# Altium schematic sheet 4_NRF9160.SchDoc(4_NRF9160)
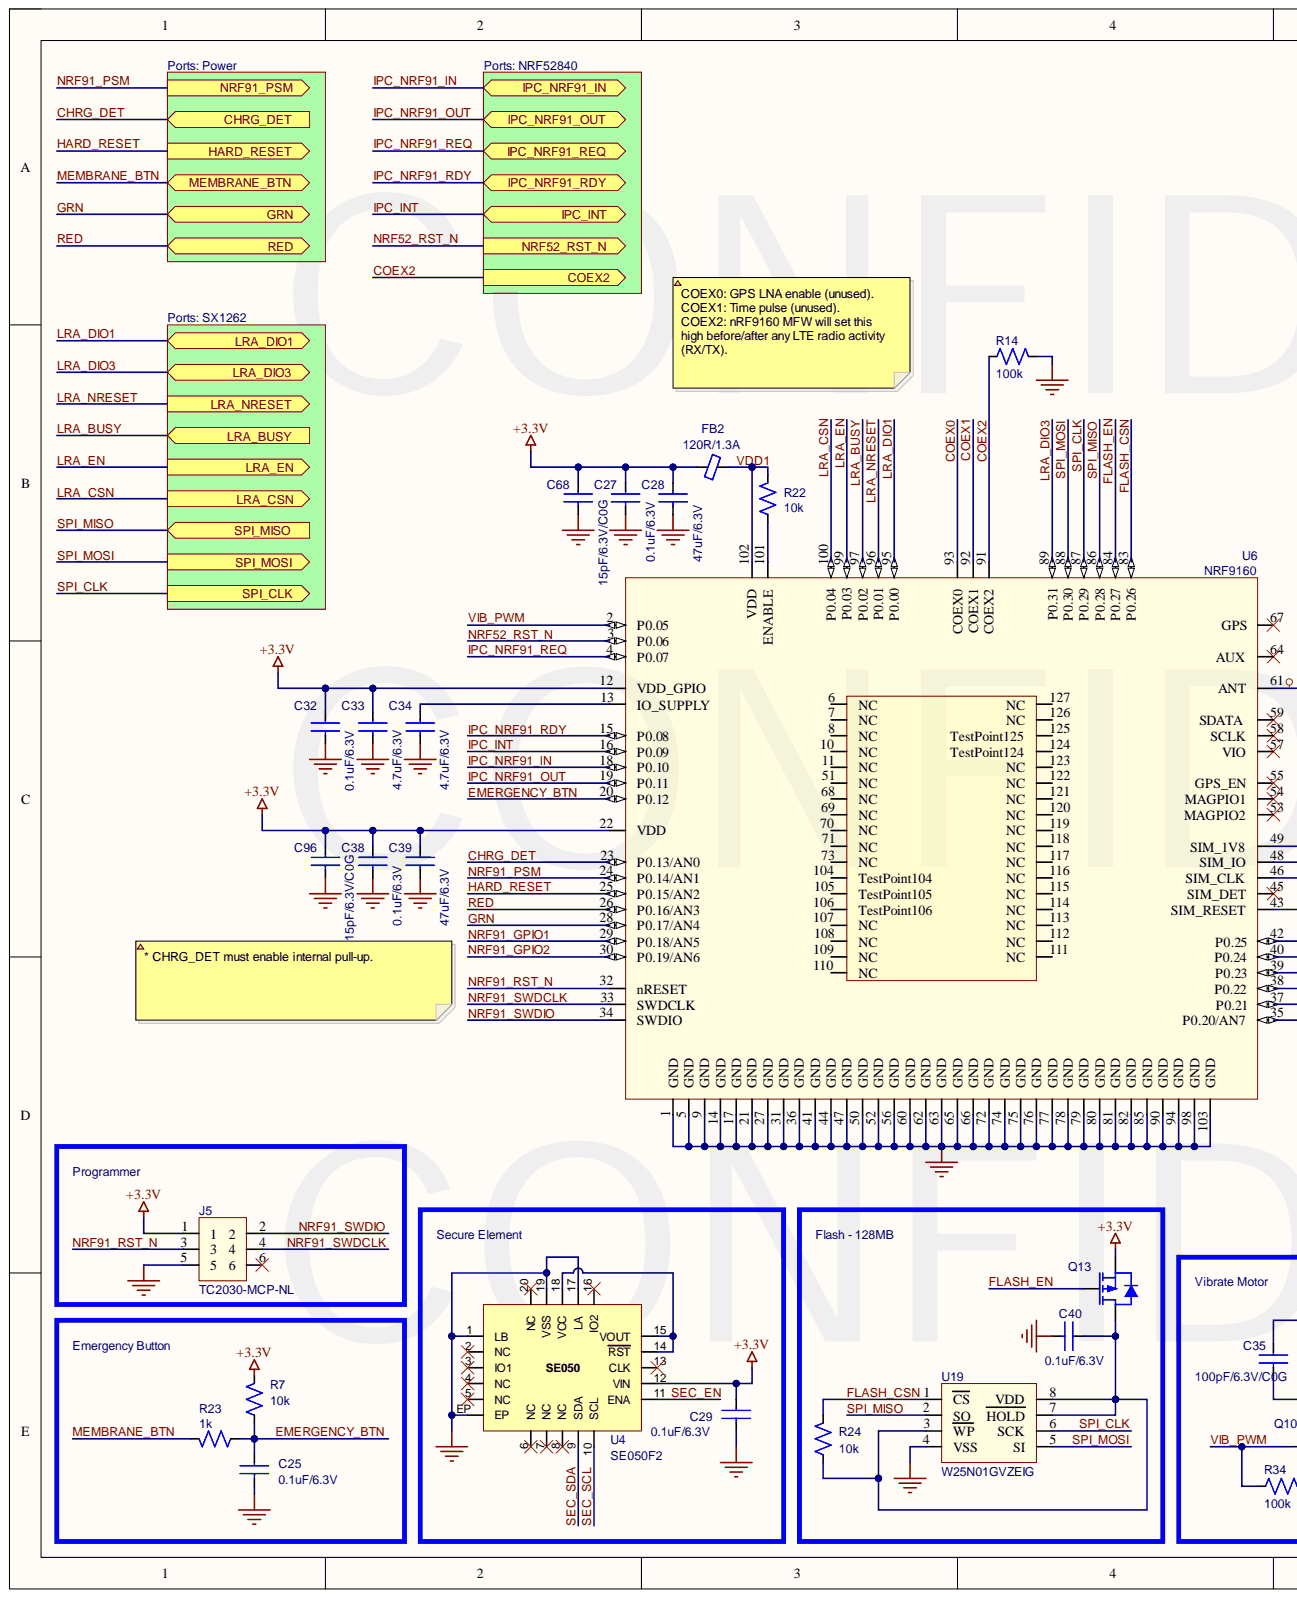
[diagram p0: 4_NRF9160.SchDoc, left]
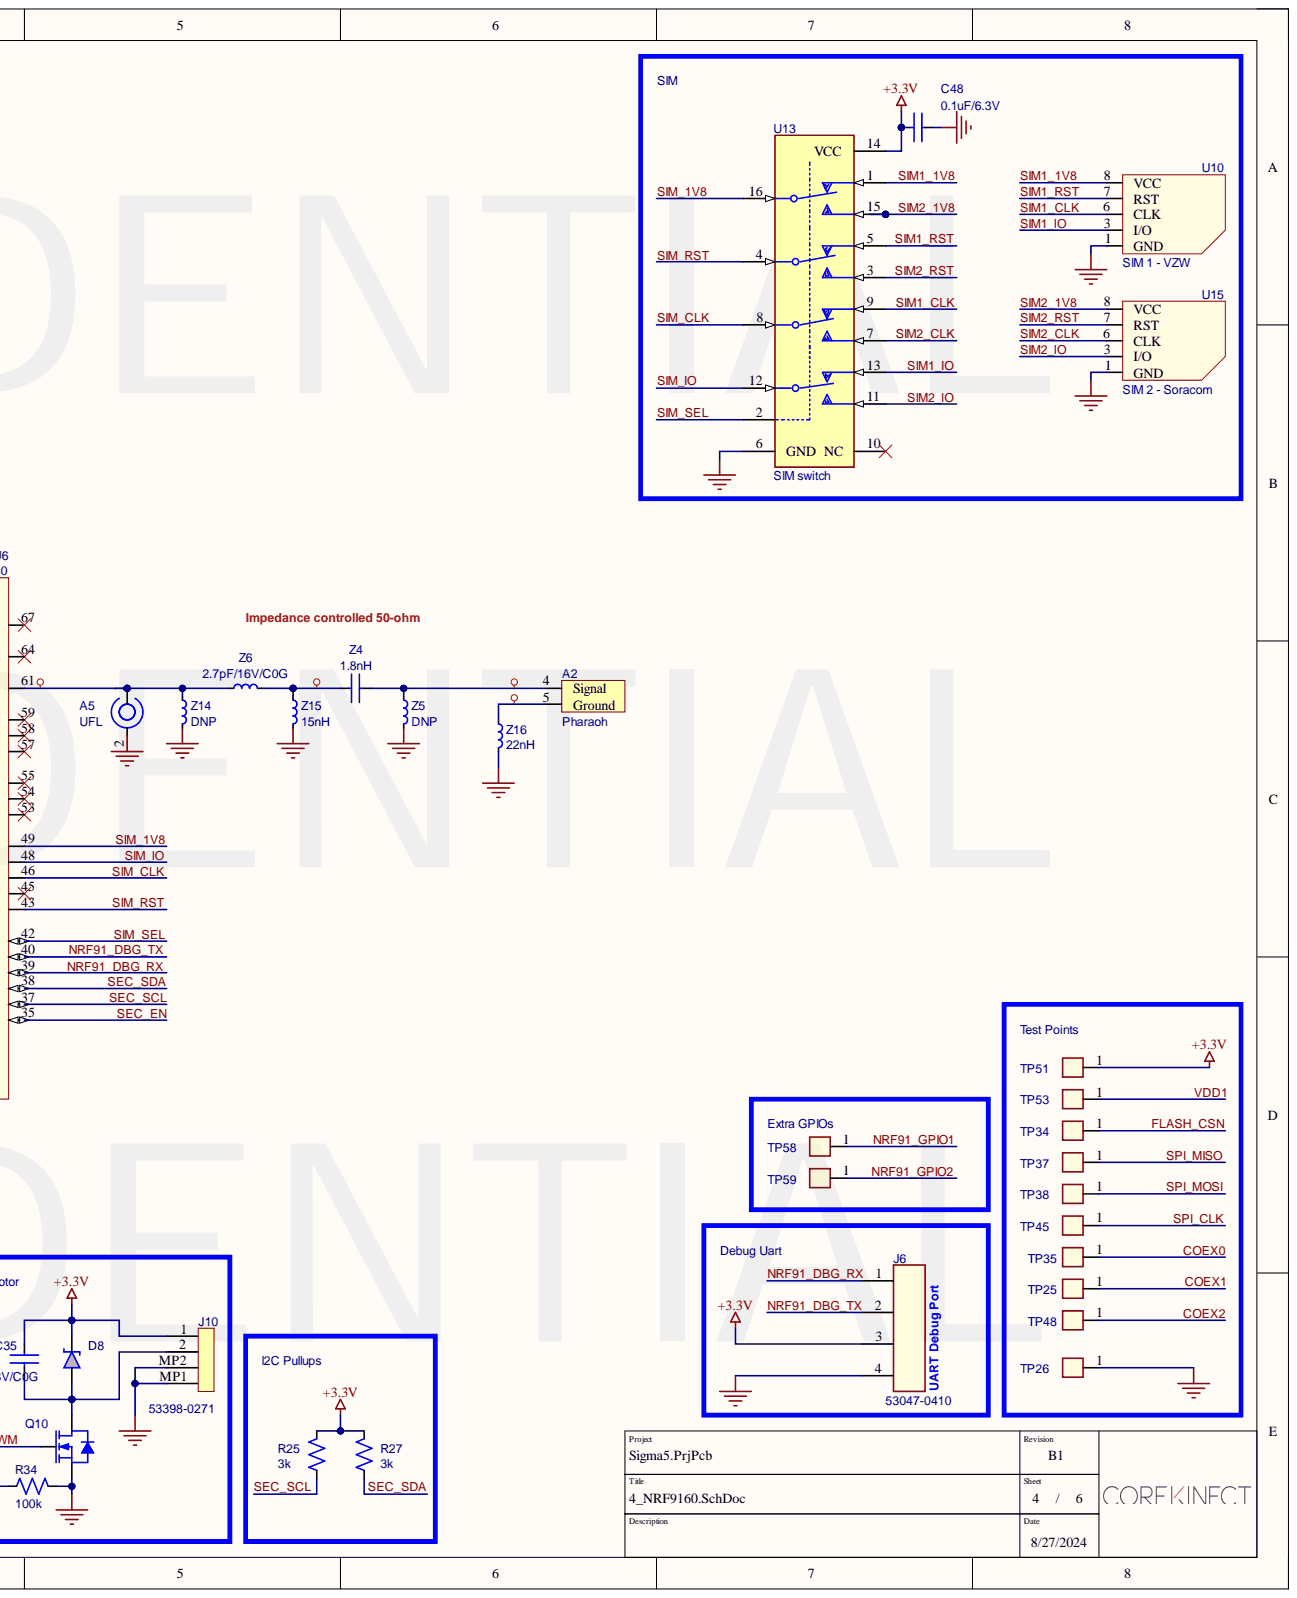
[diagram p1: 4_NRF9160.SchDoc, right]

## Parts
A2  Pharaoh  [Pharaoh_Ant]
A5  UFL  [U.FL-INLINE]
C25  0.1uF/6.3V  [CAP_0402]
C27  0.1uF/6.3V  [CAP_0402]
C28  47uF/6.3V  [SMT_0805]
C29  0.1uF/6.3V  [CAP_0402]
C32  0.1uF/6.3V  [CAP_0402]
C33  4.7uF/6.3V  [CAP_0603]
C34  4.7uF/6.3V  [CAP_0603]
C35  100pF/6.3V/C0G  [CAP_0402]
C38  0.1uF/6.3V  [CAP_0402]
C39  47uF/6.3V  [SMT_0805]
C40  0.1uF/6.3V  [CAP_0402]
C48  0.1uF/6.3V  [CAP_0402]
C68  15pF/6.3V/C0G  [CAP_0402]
C96  15pF/6.3V/C0G  [CAP_0402]
D8  B140HW-7  [SOD123]  (value not drawn)
FB2  120R/1.3A  [CAP_0402]
J5  TC2030-MCP-NL  [TC2030-MCP-NL]
J6  53047-0410  [0530470410_Molex]
J10  53398-0271  [MOLEX-53398-0271_V]
Q10  DMG1012UW-7  [SOT-323]  (value not drawn)
Q13  DMG1013UW-7  [SOT-323]  (value not drawn)
R7  10k  [RES_0402]
R14  100k  [RES_0402]
R22  10k  [RES_0402]
R23  1k  [RES_0402]
R24  10k  [RES_0402]
R25  3k  [RES_0402]
R27  3k  [RES_0402]
R34  100k  [RES_0402]
TP25  TP  [SMT_TP35_SM]
TP26  TP  [SMT_TP35_SM]
TP34  TP  [SMT_TP35_SM]
TP35  TP  [SMT_TP35_SM]
TP37  TP  [SMT_TP35_SM]
TP38  TP  [SMT_TP35_SM]
TP45  TP  [SMT_TP35_SM]
TP48  TP  [SMT_TP35_SM]
TP51  TP  [SMT_TP35_SM]
TP53  TP  [SMT_TP35_SM]
TP58  TP  [SMT_TP35_SM]
TP59  TP  [SMT_TP35_SM]
U4  SE050F2  [NXP_SE050]
U6  NRF9160  [nRF9160_DEV2_MODULE]
U10  SIM 1 - VZW  [SIM-ST-MFF2]
U13  SIM switch  [FP-523BF-MFG]
U15  SIM 2 - Soracom  Manufacturer 1: Soracom  [SIM-ST-MFF2]
U19  W25N01GVZEIG  [WSON-8_8x6mm]
Z4  1.8nH  [CAP_0402]
Z5  DNP  [CAP_0402]
Z6  2.7pF/16V/C0G  [CAP_0402]
Z14  DNP  [CAP_0402]
Z15  15nH  [CAP_0402]
Z16  22nH  [CAP_0402]

## Ports
CHRG_DET, COEX2, GRN, HARD_RESET, IPC_INT, IPC_NRF91_IN, IPC_NRF91_OUT, IPC_NRF91_RDY, IPC_NRF91_REQ, LRA_BUSY, LRA_CSN, LRA_DIO1, LRA_DIO3, LRA_EN, LRA_NRESET, MEMBRANE_BTN, NRF52_RST_N, NRF91_PSM, RED, SPI_CLK, SPI_MISO, SPI_MOSI

## Nets
+3.3V: C27.1 C28.1 C29.1 C32.1 C33.1 C35.1 C38.1 C39.1 C48.2 C68.1 C96.1 D8.1 FB2.1 J5.1 J6.3 J10.1 Q13.2 R7.2 R25.2 R27.2 TP51.1 U4.12(VIN) U6.12(VDD_GPIO) U6.22(VDD) U13.14(VCC)
GND: A5.2 C25.2 C27.2 C28.2 C29.2 C32.2 C33.2 C34.2 C38.2 C39.2 C40.2 C48.1 C68.2 C96.2 J5.5 J6.4 J10.MP1 J10.MP2 Q10.2 R14.2 R34.2 TP26.1 U4.1(LB) U4.17(LA) U4.19(VSS) U4.EP U6.1(GND) U6.5(GND) U6.9(GND) U6.14(GND) U6.17(GND) U6.21(GND) U6.27(GND) U6.31(GND) U6.36(GND) U6.41(GND) U6.44(GND) U6.47(GND) U6.50(GND) U6.52(GND) U6.56(GND) U6.60(GND) U6.62(GND) U6.63(GND) U6.65(GND) U6.66(GND) U6.72(GND) U6.74(GND) U6.75(GND) U6.76(GND) U6.77(GND) U6.78(GND) U6.79(GND) U6.80(GND) U6.81(GND) U6.82(GND) U6.85(GND) U6.90(GND) U6.94(GND) U6.98(GND) U6.103(GND) U10.1(GND) U13.6(GND) U15.1(GND) U19.4(VSS) Z5.2 Z14.2 Z15.2 Z16.2
CHRG_DET: U6.23(P0.13/AN0) ; port CHRG_DET
COEX0: TP35.1 U6.93(COEX0)
COEX1: TP25.1 U6.92(COEX1)
COEX2: R14.1 TP48.1 U6.91(COEX2) ; port COEX2
EMERGENCY_BTN: C25.1 R7.1 R23.1 U6.20(P0.12)
FLASH_CSN: R24.1 TP34.1 U6.83(P0.26) U19.1(CS)
FLASH_EN: Q13.1 U6.84(P0.27)
GRN: U6.28(P0.17/AN4) ; port GRN
HARD_RESET: U6.25(P0.15/AN2) ; port HARD_RESET
IPC_INT: U6.16(P0.09) ; port IPC_INT
IPC_NRF91_IN: U6.18(P0.10) ; port IPC_NRF91_IN
IPC_NRF91_OUT: U6.19(P0.11) ; port IPC_NRF91_OUT
IPC_NRF91_RDY: U6.15(P0.08) ; port IPC_NRF91_RDY
IPC_NRF91_REQ: U6.4(P0.07) ; port IPC_NRF91_REQ
LRA_BUSY: U6.97(P0.02) ; port LRA_BUSY
LRA_CSN: U6.100(P0.04) ; port LRA_CSN
LRA_DIO1: U6.95(P0.00) ; port LRA_DIO1
LRA_DIO3: U6.89(P0.31) ; port LRA_DIO3
LRA_EN: U6.99(P0.03) ; port LRA_EN
LRA_NRESET: U6.96(P0.01) ; port LRA_NRESET
MEMBRANE_BTN: R23.2 ; port MEMBRANE_BTN
NRF52_RST_N: U6.3(P0.06) ; port NRF52_RST_N
NRF91_DBG_RX: J6.1 U6.39(P0.23)
NRF91_DBG_TX: J6.2 U6.40(P0.24)
NRF91_GPIO1: TP58.1 U6.29(P0.18/AN5)
NRF91_GPIO2: TP59.1 U6.30(P0.19/AN6)
NRF91_PSM: U6.24(P0.14/AN1) ; port NRF91_PSM
NRF91_RST_N: J5.3 U6.32(nRESET)
NRF91_SWDCLK: J5.4 U6.33(SWDCLK)
NRF91_SWDIO: J5.2 U6.34(SWDIO)
RED: U6.26(P0.16/AN3) ; port RED
SEC_EN: U4.11(ENA) U6.35(P0.20/AN7)
SEC_SCL: R25.1 U4.10(SCL) U6.37(P0.21)
SEC_SDA: R27.1 U4.9(SDA) U6.38(P0.22)
SIM1_1V8: U10.8(VCC) U13.1
SIM1_CLK: U10.6(CLK) U13.9
SIM1_IO: U10.3(I/O) U13.13
SIM1_RST: U10.7(RST) U13.5
SIM2_1V8: U13.15 U15.8(VCC)
SIM2_CLK: U13.7 U15.6(CLK)
SIM2_IO: U13.11 U15.3(I/O)
SIM2_RST: U13.3 U15.7(RST)
SIM_1V8: U6.49(SIM_1V8) U13.16
SIM_CLK: U6.46(SIM_CLK) U13.8
SIM_IO: U6.48(SIM_IO) U13.12
SIM_RST: U6.43(SIM_RESET) U13.4
SIM_SEL: U6.42(P0.25) U13.2
SPI_CLK: TP45.1 U6.87(P0.29) U19.6(SCK) ; port SPI_CLK
SPI_MISO: TP37.1 U6.86(P0.28) U19.2(SO) ; port SPI_MISO
SPI_MOSI: TP38.1 U6.88(P0.30) U19.5(SI) ; port SPI_MOSI
VDD1: FB2.2 R22.2 TP53.1 U6.102(VDD)
VIB_PWM: Q10.1 R34.1 U6.2(P0.05)
NetA2_4: A2.4 Z4.2 Z5.1
NetA2_5: A2.5 Z16.1
NetA5_1: A5.1 U6.61(ANT) Z6.1 Z14.1
NetC34_1: C34.1 U6.13(IO_SUPPLY)
NetC35_2: C35.2 D8.2 J10.2 Q10.3
NetC40_1: C40.1 Q13.3 R24.2 U19.3(WP) U19.7(HOLD) U19.8(VDD)
NetR22_1: R22.1 U6.101(ENABLE)
NetU4_14: U4.14(RST) U4.15(VOUT) U4.18(VCC)
NetZ4_1: Z4.1 Z6.2 Z15.1

Not connected: J5 6; U4 2(NC) 3(IO1) 4(NC) 5(NC) 6(NC) 7(NC) 8(NC) 13(CLK) 16(IO2) 20(NC); U6 6(NC) 7(NC) 8(NC) 10(NC) 11(NC) 45(SIM_DET) 51(NC) 53(MAGPIO2) 54(MAGPIO1) 55(GPS_EN) 57(VIO) 58(SCLK) 59(SDATA) 64(AUX) 67(GPS) 68(NC) 69(NC) 70(NC) 71(NC) 73(NC) 104(TestPoint104) 105(TestPoint105) 106(TestPoint106) 107(NC) 108(NC) 109(NC) 110(NC) 111(NC) 112(NC) 113(NC) 114(NC) 115(NC) 116(NC) 117(NC) 118(NC) 119(NC) 120(NC) 121(NC) 122(NC) 123(NC) 124(TestPoint124) 125(TestPoint125) 126(NC) 127(NC); U13 10(NC)

## Library data of the parts
A2 = SR4L073: Antenna Cellular -6dBi Gain 960MHz/2200MHz/2400MHz/2690MHz 18-Pin SMD; Manufacturer: Antenova; Notes: LTE antenna; Component Kind: Standard; Manufacturer Part Number: SR4L073; Pricing 1: 1=5.19, 10=4.663, 25=4.4088, 100=3.8208, 250=3.62488 (USD); RoHS: No; Stock 1: 502; Supplier 1: Digi-Key; Supplier Part Number 1: 627-SR4L073DKR-ND; Library Name: CoreKinectIntegratedLibrary.IntLib
A5 = UFL_INLINE: Component Kind: Standard; Library Name: CoreKinectIntegratedLibrary.IntLib
C25 C27 C29 C32 C35 C38 C40 C48 C68 C96 = CAP_0402: Component Kind: Standard; Package / Case: 0402; Library Name: CoreKinectIntegratedLibrary.IntLib
C28 C39 = CAP_0805: Component Kind: Standard; Package / Case: 0805; Library Name: CoreKinectIntegratedLibrary.IntLib
C33 C34 = CAP_0603: Component Kind: Standard; Package / Case: 0603; Library Name: CoreKinectIntegratedLibrary.IntLib
D8 = B140HW-7: Diode Schottky 40V 1A 2Pin SOD123; Manufacturer 1: Diodes; Element Configuration: Single; Forward Voltage: 550mV; Max Forward Surge Current (Ifsm): 16A; Max Power Dissipation: 410mW; Max Reverse Current: 40uA; Polarity: Standard; Average Rectified Current: 1A; Category: Rectifier Diodes; Component Kind: Standard; ComponentLink1Description: https://datasheet.ciiva.com/25946/ds30670-25946913.pdf?src-supplier=Avnet+Europe; ComponentLink1URL: https://datasheet.ciiva.com/25946/ds30670-25946913.pdf?src-supplier=Avnet+Europe; ComponentLink2Description: https://datasheet.ciiva.com/4715/ds30670-86720-4715619.pdf?src-supplier=Mouser; ComponentLink2URL: https://datasheet.ciiva.com/4715/ds30670-86720-4715619.pdf?src-supplier=Mouser; ComponentLink3Description: https://datasheet.ciiva.com/26788/b140hw-7-26788582.pdf?src-supplier=IIIC; ComponentLink3URL: https://datasheet.ciiva.com/26788/b140hw-7-26788582.pdf?src-supplier=IIIC; ComponentLink4Description: https://datasheet.ciiva.com/912/ds30670-912807.pdf?src-supplier=Digi-Key; ComponentLink4URL: https://datasheet.ciiva.com/912/ds30670-912807.pdf?src-supplier=Digi-Key; ComponentLink5Description: https://datasheet.ciiva.com/912/ds30670-912808.pdf?src-supplier=Digi-Key; ComponentLink5URL: https://datasheet.ciiva.com/912/ds30670-912808.pdf?src-supplier=Digi-Key; ComponentLink6Description: https://datasheet.ciiva.com/912/ds30670-912809.pdf?src-supplier=Digi-Key; ComponentLink6URL: https://datasheet.ciiva.com/912/ds30670-912809.pdf?src-supplier=Digi-Key; ComponentLink7Description: https://datasheet.ciiva.com/8301/ds30670-8301643.pdf?src-supplier=Avnet; ComponentLink7URL: https://datasheet.ciiva.com/8301/ds30670-8301643.pdf?src-supplier=Avnet; ComponentLink8Description: https://datasheet.ciiva.com/8303/ds30670-8303271.pdf?src-supplier=Avnet; ComponentLink8URL: https://datasheet.ciiva.com/8303/ds30670-8303271.pdf?src-supplier=Avnet; ComponentLink9Description: https://datasheet.ciiva.com/1177/ds30670-1177415.pdf?src-supplier=Element14; ComponentLink9URL: https://datasheet.ciiva.com/1177/ds30670-1177415.pdf?src-supplier=Element14; ComponentLink10Description: https://datasheet.ciiva.com/15220/getdatasheetpartid-245799-15220198.pdf?src-supplier=Verical; ComponentLink10URL: https://datasheet.ciiva.com/15220/getdatasheetpartid-245799-15220198.pdf?src-supplier=Verical; Contact Plating: Tin,Matte; Current Rating: 1A; Forward Current: 1A; Height: 1.25mm; Lead Free: Lead Free; Length: 2.85mm; Manufacturer Part Number 1: B140HW-7; Max Operating Temperature: 125°C; Max Repetitive Reverse Voltage (Vrrm): 40V; Max Reverse Voltage (DC): 40V; Min Operating Temperature: -65°C; Mount: Surface Mount; Number of Pins: 2; Output Current: 1A; Package Quantity: 3000; Packaging: Tape and Reel; Peak Non-Repetitive Surge Current: 16A; Pricing 1: 3000=0.08800, 6000=0.07920, 15000=0.07040, 30000=0.06680 (USD); Radiation Hardening: No; REACH SVHC: No SVHC; RoHS: Yes; RoHS Compliant: Yes; Series: -; Stock 1: 84000; Supplier 1: Digi-Key; Supplier Part Number 1: B140HWDITR-ND; Voltage Rating (DC): 40V; Weight: 0.000353oz; Width: 1.7mm; Library Name: CoreKinectIntegratedLibrary.IntLib
FB2 = BLM15PD121SN1D: Ferrite Bead, 120 ohm @ 100MHz, 1.3 A, BLM15PD Series, 0.09 ohm, ± 25%; Manufacturer 1: Murata; Current Rating: 1.3A; DC Resistance (DCR): 90mR; Impedance: 120R; Material: Steel,Ferrite; Number of Circuits: 1; Case Code (Imperial): 0402; Case Code (Metric): 1005; Case/Package: 0402; Category: Inductors; Component Kind: Standard; ComponentLink1Description: https://datasheet.ciiva.com/18093/getdatasheetpartid-195858-18093157.pdf?src-supplier=Verical; ComponentLink1URL: https://datasheet.ciiva.com/18093/getdatasheetpartid-195858-18093157.pdf?src-supplier=Verical; ComponentLink2Description: https://datasheet.ciiva.com/11800/0900766b811b5866-11800860.pdf?src-supplier=RSComponents; ComponentLink2URL: https://datasheet.ciiva.com/11800/0900766b811b5866-11800860.pdf?src-supplier=RSComponents; ComponentLink3Description: https://datasheet.ciiva.com/41425/001171686-da-01-en-chip-drossel0402-120r-1300ma-1-0x0-5mm-41425776.pdf?src-supplier=Conrad; ComponentLink3URL: https://datasheet.ciiva.com/41425/001171686-da-01-en-chip-drossel0402-120r-1300ma-1-0x0-5mm-41425776.pdf?src-supplier=Conrad; ComponentLink4Description: https://datasheet.ciiva.com/41449/001172205-da-01-en-drossel-0402-120r-11-0x0-5mm-10000st-41449495.pdf?src-supplier=Conrad; ComponentLink4URL: https://datasheet.ciiva.com/41449/001172205-da-01-en-drossel-0402-120r-11-0x0-5mm-10000st-41449495.pdf?src-supplier=Conrad; ComponentLink5Description: https://datasheet.ciiva.com/1012/l0110e-1012436.pdf?src-supplier=Digi-Key; ComponentLink5URL: https://datasheet.ciiva.com/1012/l0110e-1012436.pdf?src-supplier=Digi-Key; ComponentLink6Description: https://datasheet.ciiva.com/1012/l0110e-1012434.pdf?src-supplier=Digi-Key; ComponentLink6URL: https://datasheet.ciiva.com/1012/l0110e-1012434.pdf?src-supplier=Digi-Key; ComponentLink7Description: https://datasheet.ciiva.com/1012/l0110e-1012435.pdf?src-supplier=Digi-Key; ComponentLink7URL: https://datasheet.ciiva.com/1012/l0110e-1012435.pdf?src-supplier=Digi-Key; Core Material: Ferrite; DC Current: 1.3A; DC Resistance-Max: 90mR; Depth: 0.55mm; Height: 0.5mm; Lead Free: Lead Free; Length: 1mm; Manufacturer Part Number 1: BLM15PD121SN1D; Max Current Rating: 1.3A; Max DC Current: 1.3A; Max Operating Temperature: 125°C; Military Standard: Not; Min Operating Temperature: -55°C; Number of Lines: 1; Number of Pins: 2; Package Quantity: 1; Packaging: Tape and Reel; Pricing 1: 10000=0.01914, 20000=0.01848, 30000=0.01716, 50000=0.01696 (USD); Radiation Hardening: No; REACH SVHC: No SVHC; RoHS: Yes; RoHS Compliant: Yes; Series: BLM15PD; Stock 1: 240000; Supplier 1: Digi-Key; Supplier Part Number 1: 490-5203-2-ND; Termination: SMD/SMT; Test Frequency: 100MHz; Tolerance: 25%; Width: 0.5mm; Library Name: CoreKinectIntegratedLibrary.IntLib
J5 = TC2030-MCP-NL: CABLE TAG-CONNECT IN-CIRCUIT; Component Kind: Standard; PN: TC2030-MCP-NL; Library Name: Project Zenith.SchLib
J6 = MOLEX_53047-0410: GLOVE, POLYAMIDE, L/FREE, PU, SIZE 6 - More Details; Manufacturer 1: Molex; Contact Resistance: 20mR; Current: 1A; Gender: Male; Glow Wire Compliant: Non-compliant; Insulation Resistance: 100MR; Max Voltage Rating (AC): 125V; Number of Circuits: 4; Pitch: 1.25mm; Plating: Tin; Case/Package: 0410; Category: Headers and Wire Housings; Color: Natural; Component Kind: Standard; ComponentLink1Description: https://datasheet.ciiva.com/7844/1838335-7844032.pdf?src-supplier=Element14; ComponentLink1URL: https://datasheet.ciiva.com/7844/1838335-7844032.pdf?src-supplier=Element14; ComponentLink2Description: https://datasheet.ciiva.com/30144/347020530470410-pcb-headers-30144214.pdf?src-supplier=Onlinecomponents.com; ComponentLink2URL: https://datasheet.ciiva.com/30144/347020530470410-pcb-headers-30144214.pdf?src-supplier=Onlinecomponents.com; ComponentLink3Description: https://datasheet.ciiva.com/20207/22-3930e-20207527.pdf?src-supplier=Rapid+Electronics; ComponentLink3URL: https://datasheet.ciiva.com/20207/22-3930e-20207527.pdf?src-supplier=Rapid+Electronics; ComponentLink4Description: https://datasheet.ciiva.com/5149/0530470410-pcb-headers-170953-5149004.pdf?src-supplier=Mouser; ComponentLink4URL: https://datasheet.ciiva.com/5149/0530470410-pcb-headers-170953-5149004.pdf?src-supplier=Mouser; ComponentLink5Description: https://datasheet.ciiva.com/11746/0900766b8024e2fd-11746351.pdf?src-supplier=RSComponents; ComponentLink5URL: https://datasheet.ciiva.com/11746/0900766b8024e2fd-11746351.pdf?src-supplier=RSComponents; ComponentLink6Description: https://datasheet.ciiva.com/16658/0530470410-pcb-headers-16658419.pdf?src-supplier=Avnet+Europe; ComponentLink6URL: https://datasheet.ciiva.com/16658/0530470410-pcb-headers-16658419.pdf?src-supplier=Avnet+Europe; ComponentLink7Description: https://datasheet.ciiva.com/27054/1932483-27054996.pdf?src-supplier=Jameco; ComponentLink7URL: https://datasheet.ciiva.com/27054/1932483-27054996.pdf?src-supplier=Jameco; ComponentLink8Description: https://datasheet.ciiva.com/14156/ge116819-116832-e-14156235.pdf?src-supplier=Distrelec; ComponentLink8URL: https://datasheet.ciiva.com/14156/ge116819-116832-e-14156235.pdf?src-supplier=Distrelec; ComponentLink9Description: https://datasheet.ciiva.com/10088/0530470410-pcb-headers-10088984.pdf?src-supplier=Avnet; ComponentLink9URL: https://datasheet.ciiva.com/10088/0530470410-pcb-headers-10088984.pdf?src-supplier=Avnet; Contact Material: Bronze,Tin; Contact Plating: Tin; Current Rating: 1A; Flammability Rating: UL94 V-0; Housing Color: Natural; Housing Material: Polyamide; Lead Free: Lead Free; Manufacturer Part Number 1: 53047-0410; Max Operating Temperature: 85°C; Min Operating Temperature: -40°C; Mount: Through Hole; Number of Contacts: 4; Number of Positions: 4; Number of Rows: 1; Orientation: Vertical; Package Quantity: 5000; Packaging: Tray; Pricing 1: 1=0.28000, 10=0.26100, 100=0.18300, 500=0.15688, 1000=0.13073, 5000=0.11205, 10000=0.10458 (USD); Radiation Hardening: No; REACH SVHC: Unknown; RoHS: Yes; Stock 1: 47330; Supplier 1: Digi-Key; Supplier Part Number 1: WM1733-ND; Termination: Solder; Voltage: 125V; Voltage Rating: 125V; Voltage Rating (AC): 125V; Voltage Rating (DC): 125V; Working Voltage: 125V; Library Name: CoreKinectIntegratedLibrary.IntLib
J10 = MOLEX_53398-0271: 1.25mm (.049") Pitch PicoBlade Header, Surface Mount, Vertical, Lead-free, 2 Circuits; Manufacturer: Molex; Contact Resistance: 20mR; Current Rating: 1A; Gender: Male; Glow Wire Compliant: Non-compliant; Insulation Resistance: 100MR; Number of Circuits: 2; Voltage Rating: 125V; Category: Headers and Wire Housings; Color: Natural; Component Kind: Standard; Contact Material: Bronze,Brass,Tin; Contact Plating: Tin; Contact Type: Blade Pin; Flammability Rating: UL94 V-0; Housing Color: Natural; Housing Material: Nylon; Lead Free: Lead Free; Manufacturer Part Number: 53398-0271; Max Operating Temperature: 85°C; Min Operating Temperature: -40°C; Mount: Surface Mount; Number of Contacts: 2; Number of Positions: 2; Number of Rows: 1; Orientation: Vertical; Package Quantity: 1000; Packaging: Tape and Reel; Pitch: 1.25mm; Pricing 1: 1=0.65, 10=0.551, 100=0.4284 (USD); Radiation Hardening: No; Reach SVHC Compliant: Unknown; RoHS: Yes; RoHS Compliant: Yes; Series: PicoBlade; Stock 1: 149643; Supplier 1: Digi-Key; Supplier Part Number 1: WM7606DKR-ND; Termination: Solder; Voltage Rating (AC): 125V; Voltage Rating (DC): 125V; Working Voltage: 125V; Library Name: CoreKinectIntegratedLibrary.IntLib
Q10 = DMG1012UW-7: MOSFET N-CH 20V 1A SOT323; Manufacturer: Diodes Zetex; Drain to Source Resistance: 300mR; Fall Time: 12.3ns; Gate to Source Voltage (Vgs): 6V; Input Capacitance: 60.67pF; Max Power Dissipation: 290mW; Number of Channels: 1; Polarity: N-CHANNEL; Rds On Max: 450mR; Rise Time: 7.4ns; Turn-Off Delay Time: 26.7ns; Turn-On Delay Time: 5.1ns; Case/Package: SOT-323; Category: MOSFETs; Component Kind: Standard; ComponentLink1Description: https://datasheet.ciiva.com/4443/ds31859-90313-4443117.pdf?src-supplier=Mouser; ComponentLink1URL: https://datasheet.ciiva.com/4443/ds31859-90313-4443117.pdf?src-supplier=Mouser; ComponentLink2Description: https://datasheet.ciiva.com/11174/ds31859-11174966.pdf?src-supplier=Avnet; ComponentLink2URL: https://datasheet.ciiva.com/11174/ds31859-11174966.pdf?src-supplier=Avnet; ComponentLink3Description: https://datasheet.ciiva.com/6383/ds31859-6383798.pdf?src-supplier=Digi-Key; ComponentLink3URL: https://datasheet.ciiva.com/6383/ds31859-6383798.pdf?src-supplier=Digi-Key; ComponentLink4Description: https://datasheet.ciiva.com/6383/ds31859-6383800.pdf?src-supplier=Digi-Key; ComponentLink4URL: https://datasheet.ciiva.com/6383/ds31859-6383800.pdf?src-supplier=Digi-Key; Continuous Drain Current (ID): 1A; Drain to Source Voltage (Vdss): 20V; Height: 1mm; Lead Free: Lead Free; Length: 2.2mm; Manufacturer Part Number: DMG1012UW-7; Max Operating Temperature: 150°C; Min Operating Temperature: -55°C; Mount: Surface Mount; Number of Pins: 3; Package Quantity: 3000; Packaging: Tape and Reel; Pricing 1: 1=0.35, 10=0.283, 100=0.1499, 500=0.09862, 1000=0.06706 (USD); Radiation Hardening: No; Reach SVHC Compliant: Yes; RoHS: Yes; RoHS Compliant: Yes; Series: -; Stock 1: 770121; Supplier 1: Digi-Key; Supplier Part Number 1: DMG1012UW-7DICT-ND; Weight: 0.000212oz; Width: 1.35mm; Library Name: CoreKinectIntegratedLibrary.IntLib
Q13 = DMG1013UW-7: DMG1013UW Series 20 V 750 mOhm P-Channel Enhancement Mode Mosfet - SOT-323; Manufacturer: Diodes; Continuous Drain Current (ID): 820mA; Drain to Source Resistance: 1.5R; Drain to Source Voltage (Vdss): 20V; Drain-source On Resistance-Max: 750mR; Fall Time: 20.7ns; Gate to Source Voltage (Vgs): 6V; Input Capacitance: 59.76pF; Max Power Dissipation: 310mW; Number of Channels: 1; Rise Time: 8.1ns; Threshold Voltage: -1V; Turn-Off Delay Time: 28.4ns; Turn-On Delay Time: 5.1ns; Case/Package: SOT-323; Category: MOSFETs; Component Kind: Standard; ComponentLink1Description: https://datasheet.ciiva.com/4442/ds31861-67449-4442919.pdf?src-supplier=Mouser; ComponentLink1URL: https://datasheet.ciiva.com/4442/ds31861-67449-4442919.pdf?src-supplier=Mouser; ComponentLink2Description: https://datasheet.ciiva.com/16369/ds31861-16369875.pdf?src-supplier=Future+Electronics; ComponentLink2URL: https://datasheet.ciiva.com/16369/ds31861-16369875.pdf?src-supplier=Future+Electronics; ComponentLink3Description: https://datasheet.ciiva.com/11174/ds31861-11174967.pdf?src-supplier=Avnet; ComponentLink3URL: https://datasheet.ciiva.com/11174/ds31861-11174967.pdf?src-supplier=Avnet; ComponentLink4Description: https://datasheet.ciiva.com/934/ds31861-934533.pdf?src-supplier=Digi-Key; ComponentLink4URL: https://datasheet.ciiva.com/934/ds31861-934533.pdf?src-supplier=Digi-Key; ComponentLink5Description: https://datasheet.ciiva.com/934/ds31861-934534.pdf?src-supplier=Digi-Key; ComponentLink5URL: https://datasheet.ciiva.com/934/ds31861-934534.pdf?src-supplier=Digi-Key; ComponentLink6Description: https://datasheet.ciiva.com/934/ds31861-934532.pdf?src-supplier=Digi-Key; ComponentLink6URL: https://datasheet.ciiva.com/934/ds31861-934532.pdf?src-supplier=Digi-Key; Height: 1mm; Lead Free: Lead Free; Length: 2.2mm; Manufacturer Part Number: DMG1013UW-7; Max Operating Temperature: 150°C; Min Operating Temperature: -55°C; Mount: Surface Mount; Number of Pins: 3; Package Quantity: 3000; Packaging: Tape and Reel; Polarity: P-CHANNEL; Pricing 1: 1=0.35, 10=0.283, 100=0.1499, 500=0.09862, 1000=0.06706 (USD); Radiation Hardening: No; Rds On Max: 750mR; Reach SVHC Compliant: No; RoHS: Yes; RoHS Compliant: Yes; Series: -; Stock 1: 419828; Supplier 1: Digi-Key; Supplier Part Number 1: DMG1013UW-7DIDKR-ND; Weight: 0.000212oz; Width: 1.35mm; Library Name: CoreKinectIntegratedLibrary.IntLib
R7 R14 R22-R25 R27 R34 = RES_0402: Component Kind: Standard; Package / Case: 0402; Library Name: CoreKinectIntegratedLibrary.IntLib
TP25 TP26 TP34 TP35 TP37 TP38 TP45 TP48 TP51 TP53 TP58 TP59 = CON1_1: PN: SMT_TP35; Component Kind: Standard
U4 = SE050F2: NXP EdgeLock Secure Element; Manufacturer 1: NXP Semiconductors; Manufacturer Part Number 1: SE050F2HQ1/Z018HZ; Category: Uncategorized; Component Kind: Standard; Pricing 1: 3000=2.13928, 6000=2.09440, 12000=2.03456, 18000=2.00464, 24000=1.95976, 30000=1.91488, 300000=1.85504 (USD); RoHS: No; Supplier 1: Avnet; Supplier Part Number 1: SE050F2HQ1/Z018HZ; Library Name: CoreKinectIntegratedLibrary.IntLib
U6 = NRF9160-SICA-R7: Rf Transceiver, 2.2Ghz, -40 To 85Deg C Rohs Compliant: Yes; Manufacturer 1: Nordic Semiconductor; Manufacturer Part Number 1: NRF9160-SICA-B1A-R; Component Kind: Standard; PN: NRF9160-SICA-R7; Pricing 1: 100=18.71390, 300=17.85203, 500=16.99022, 1000=16.00528, 2500=15.97200 (USD); RoHS: No; Stock 1: 4500; Supplier 1: Digi-Key; Supplier Part Number 1: 1490-1076-2-ND; Library Name: CoreKinectIntegratedLibrary.IntLib
U10 = SIM-ST-MFF2: VERIZON IOT EMBEDDED SIM CHIP; Manufacturer 1: STMicroelectronics; Manufacturer Part Number 1: OIMMA8MBHP01701$; Component Kind: Standard; Library Name: PERS-REVA0.SCHLIB
U13 = FSA2567UMX: FSA Series 4PDT 4.3 V 6 Ohm SMT Low Power Dual SIM Card Analog Switch - UMLP-16; Manufacturer: ON Semiconductor / Fairchild; -3db Bandwidth: 475MHz; Max Supply Current: 15uA; Max Supply Voltage: 4.3V; Min Supply Voltage: 2.7V; Number of Channels: 1; Number of Inputs: 4; Number of Outputs: 8; Supply Current: 1uA; Supply Type: Single; Category: Interface ICs; Component Kind: Standard; ComponentLink1Description: https://datasheet.ciiva.com/17282/fsa2567-17282640.pdf?src-supplier=Future+Electronics; ComponentLink1URL: https://datasheet.ciiva.com/17282/fsa2567-17282640.pdf?src-supplier=Future+Electronics; ComponentLink2Description: https://datasheet.ciiva.com/12191/fsa2567-12191190.pdf?src-supplier=Mouser; ComponentLink2URL: https://datasheet.ciiva.com/12191/fsa2567-12191190.pdf?src-supplier=Mouser; ComponentLink3Description: https://datasheet.ciiva.com/2979/fsa2567-2979468.pdf?src-supplier=Avnet; ComponentLink3URL: https://datasheet.ciiva.com/2979/fsa2567-2979468.pdf?src-supplier=Avnet; ComponentLink4Description: https://datasheet.ciiva.com/6637/fsa2567-6637130.pdf?src-supplier=Digi-Key; ComponentLink4URL: https://datasheet.ciiva.com/6637/fsa2567-6637130.pdf?src-supplier=Digi-Key; ComponentLink5Description: https://datasheet.ciiva.com/6637/fsa2567-6637133.pdf?src-supplier=Digi-Key; ComponentLink5URL: https://datasheet.ciiva.com/6637/fsa2567-6637133.pdf?src-supplier=Digi-Key; Height: 0.5mm; Lead Free: Lead Free; Length: 1.8mm; Manufacturer Part Number: FSA2567UMX; Max Operating Temperature: 85°C; Min Operating Temperature: -40°C; Mount: Surface Mount; Number of Pins: 16; Package Quantity: 5000; Packaging: Tape and Reel; Pricing 1: 1=1.04, 10=0.926, 25=0.8792, 100=0.6751, 250=0.5966, 500=0.56522, 1000=0.43961, 2500=0.41763 (USD); Radiation Hardening: No; Reach SVHC Compliant: No; RoHS: Yes; RoHS Compliant: Yes; Series: -; Stock 1: 79567; Supplier 1: Digi-Key; Supplier Part Number 1: FSA2567UMXDKR-ND; Throw Configuration: 4PDT; Weight: 0.00654g; Width: 2.6mm; Library Name: CoreKinectIntegratedLibrary.IntLib
U15 = SIM-ST-MFF2: SORACOM IOT EMBEDDED SIM CHIP; Manufacturer Part Number 1: SGEIL01; Component Kind: Standard; Library Name: PERS-REVA0.SCHLIB
U19 = W25N01GVZEIG TR: Serial SLC NAND Flash Memory With dual/Quad SPI And Continuous Read; Manufacturer: Winbond; Frequency: 104MHz; Interface: SPI,Serial; Memory Size: 1Gb; Memory Type: FLASH,NAND,Non-Volatile; Category: Flash; Component Kind: Standard; ComponentLink1Description: http://www.winbond.com/resource-files/w25n01gv%20revl%20050918%20unsecured.pdf?src-supplier=Digi-Key; ComponentLink1URL: http://www.winbond.com/resource-files/w25n01gv%20revl%20050918%20unsecured.pdf?src-supplier=Digi-Key; Manufacturer Part Number: W25N01GVZEIG TR; Max Operating Temperature: 85°C; Min Operating Temperature: -40°C; Mount: Surface Mount; Packaging: Tape and Reel; Pricing 1: 1=3.76, 10=3.411, 25=3.3364, 50=3.3186, 100=2.9755, 250=2.96464, 500=2.8563, 1000=2.71547 (USD); RoHS: Yes; RoHS Compliant: Yes; Series: SpiFlash®; Stock 1: 137400; Supplier 1: Digi-Key; Supplier Part Number 1: W25N01GVZEIGDKR-ND; Library Name: CoreKinectIntegratedLibrary.IntLib
Z4 = CAP_0402: Notes: LTE tuning - High Q; Component Kind: Standard; Package / Case: 0402; Library Name: CoreKinectIntegratedLibrary.IntLib
Z5 Z6 Z14-Z16 = IND_0402: Notes: LTE tuning - High Q; Component Kind: Standard; Package / Case: 0402; Library Name: CoreKinectIntegratedLibrary.IntLib
